# Altium schematic sheet DC_DC_Converter.SchDoc
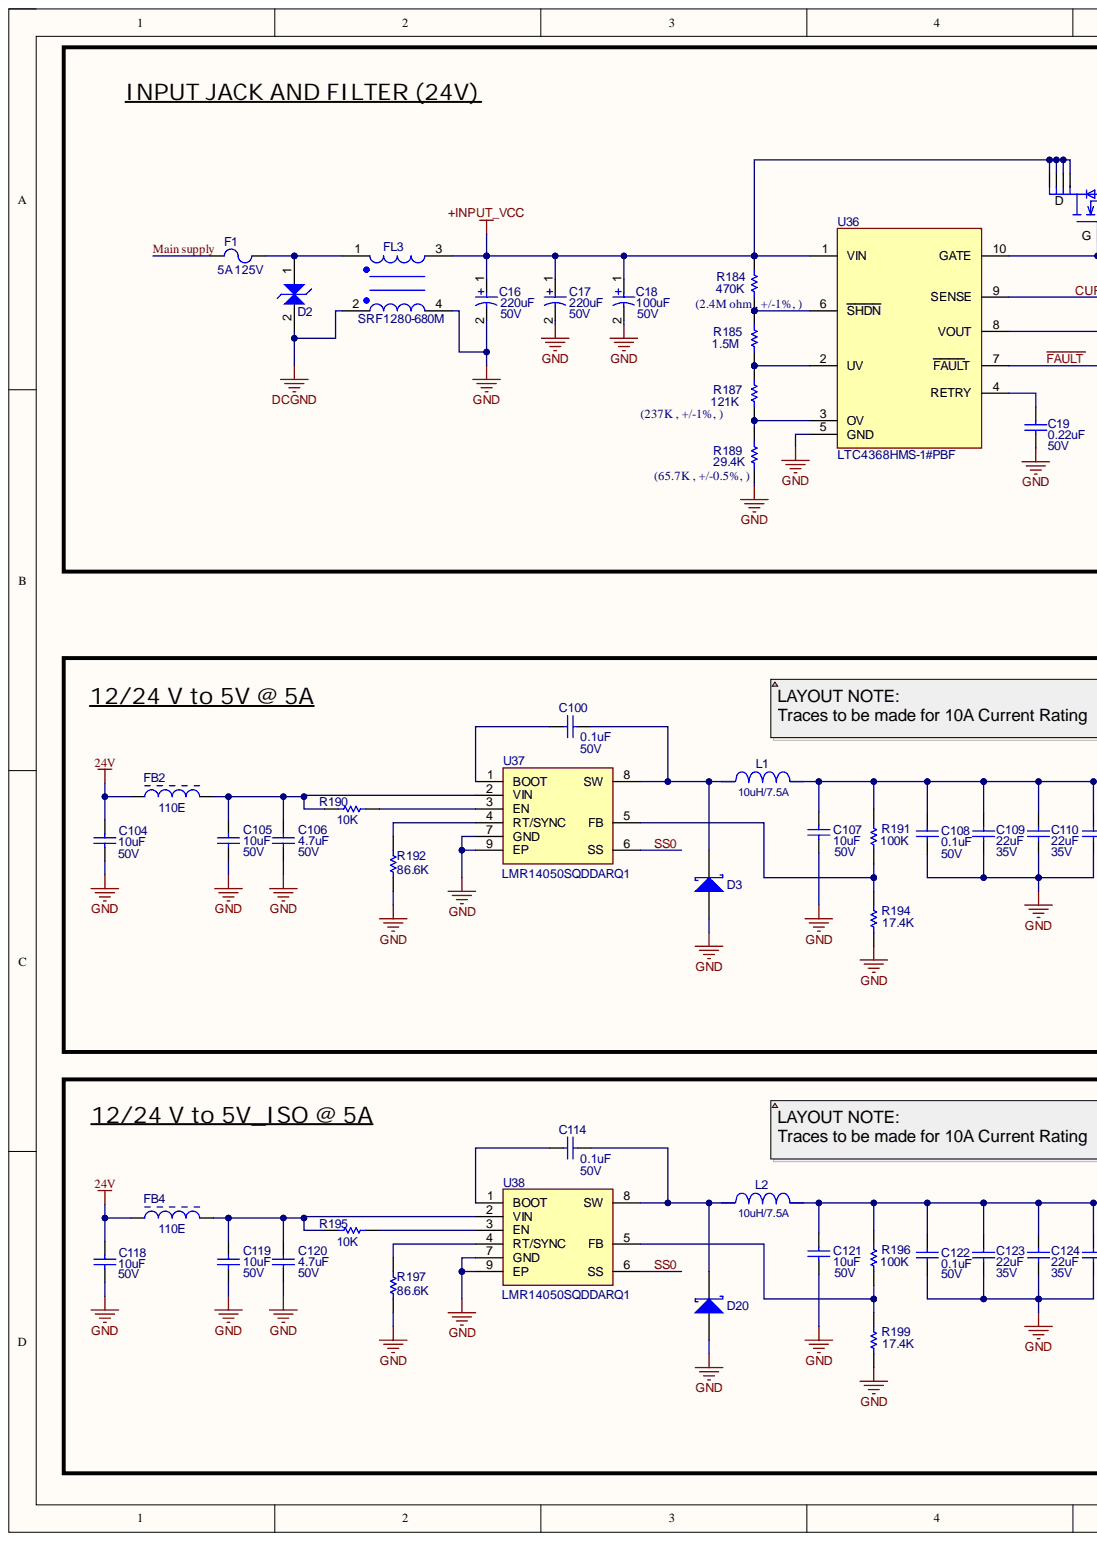
[diagram p0: DC_DC_Converter.SchDoc, left]
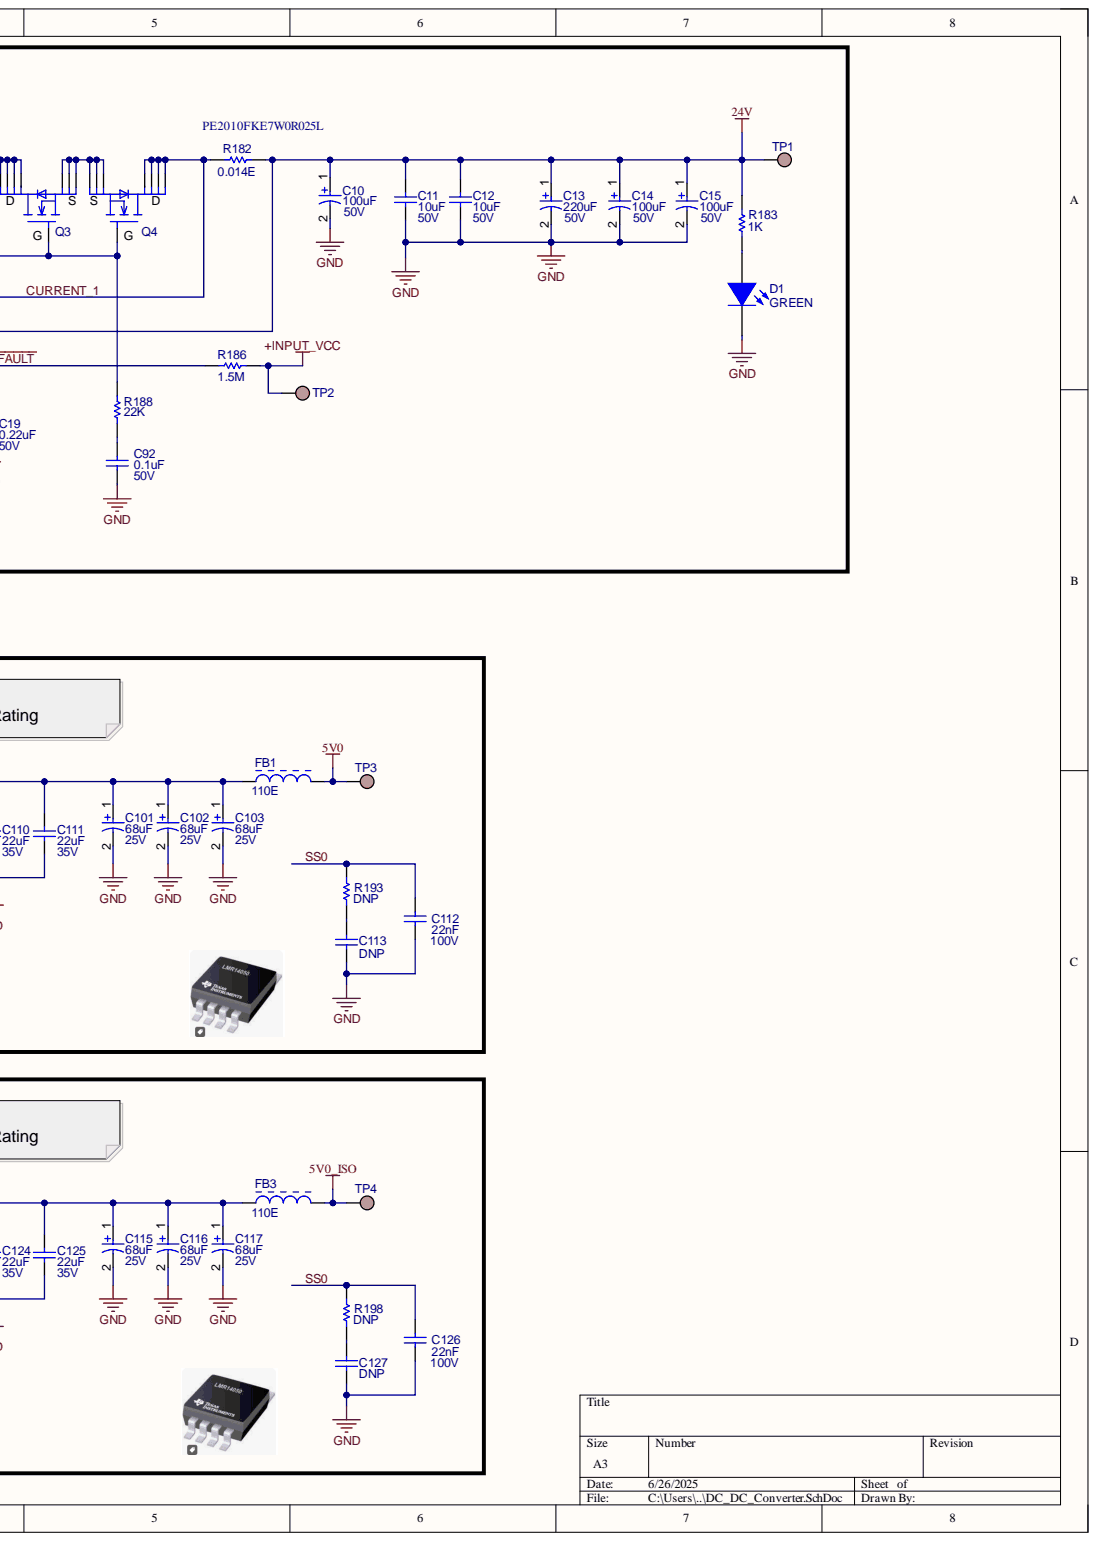
[diagram p1: DC_DC_Converter.SchDoc, right]

## Parts
C10  100uF/50V  value 100uF  Voltage Rating: 50V  [CAP_CAN_8MM]
C11  10uF  Voltage Rating: 50V  [CAP-0805]
C12  10uF  Voltage Rating: 50V  [CAP-0805]
C13  220uF/50V  value 220uF  Voltage Rating: 50V  [CAP_CAN_8MM]
C14  100uF/50V  value 100uF  Voltage Rating: 50V  [CAP_CAN_8MM]
C15  100uF/50V  value 100uF  Voltage Rating: 50V  [CAP_CAN_8MM]
C16  220uF/50V  value 220uF  Voltage Rating: 50V  [CAP_CAN_8MM]
C17  220uF/50V  value 220uF  Voltage Rating: 50V  [CAP_CAN_8MM]
C18  100uF/50V  value 100uF  Voltage Rating: 50V  [CAP_CAN_8MM]
C19  0.22uF  Voltage Rating: 50V  [CAP-0805]
C92  0.1uF  Voltage: 50V  [CAP-0805]
C100  0.1uF  Voltage: 50V  [CAP-0805]
C101  68uF  Voltage Rating: 25V  [CAP_EEHZA1H220P]
C102  68uF  Voltage Rating: 25V  [CAP_EEHZA1H220P]
C103  68uF  Voltage Rating: 25V  [CAP_EEHZA1H220P]
C104  10uF  Voltage: 50V  [CAP-0805]
C105  10uF  Voltage: 50V  [CAP-0805]
C106  4.7uF  Voltage: 50V  [CAP-0805]
C107  10uF  Voltage: 50V  [CAP-0805]
C108  0.1uF  Voltage: 50V  [CAP-0805]
C109  22uF  Voltage Rating: 35V  [CAP-0805]
C110  22uF  Voltage Rating: 35V  [CAP-0805]
C111  22uF  Voltage Rating: 35V  [CAP-0805]
C112  22nF  Voltage: 100V  [CAP-0603]
C113  DNP  [CAP-0603]
C114  0.1uF  Voltage: 50V  [CAP-0805]
C115  68uF  Voltage Rating: 25V  [CAP_EEHZA1H220P]
C116  68uF  Voltage Rating: 25V  [CAP_EEHZA1H220P]
C117  68uF  Voltage Rating: 25V  [CAP_EEHZA1H220P]
C118  10uF  Voltage: 50V  [CAP-0805]
C119  10uF  Voltage: 50V  [CAP-0805]
C120  4.7uF  Voltage: 50V  [CAP-0805]
C121  10uF  Voltage: 50V  [CAP-0805]
C122  0.1uF  Voltage: 50V  [CAP-0805]
C123  22uF  Voltage Rating: 35V  [CAP-0805]
C124  22uF  Voltage Rating: 35V  [CAP-0805]
C125  22uF  Voltage Rating: 35V  [CAP-0805]
C126  22nF  Voltage: 100V  [CAP-0603]
C127  DNP  [CAP-0603]
D1  value GREEN  [LED_0805]  (hidden comment: LTST-C170GKT)
D2  SMAJ40CA  [DO-214AC]  (value not drawn)
D3  SK810L-TP  [DO-214AB (SMC)]  (value not drawn)
D20  SK810L-TP  [DO-214AB (SMC)]  (value not drawn)
F1  5A 125V  Current - Hold: 5A  [FUSE_0157005.DR]
FB1  110E  [FB-0805]
FB2  110E  [FB-0805]
FB3  110E  [FB-0805]
FB4  110E  [FB-0805]
FL3  SRF1280-680M  [SRF1280-680M]
L1  10uH/7.5A  [APCI00121210100T80]
L2  10uH/7.5A  [APCI00121210100T80]
Q3  100V 18.8A  [DFN-8 (5x6)]  (value not drawn)
Q4  100V 18.8A  [DFN-8 (5x6)]  (value not drawn)
R182  0.014E  [RES_2725]
R183  1K  [RES_0805]
R184  470K  [RES_0805]
R185  1.5M  [RES_0805]
R186  1.5M  [RES_0805]
R187  121K  [RES_0805]
R188  22K  [RES-0603]
R189  29.4K  [RES_0805]
R190  10K  [RES-0603]
R191  100K  [RES_0805]
R192  86.6K  [RES_0805]
R193  DNP  [RES-0603]
R194  17.4K  [RES_0805]
R195  10K  [RES-0603]
R196  100K  [RES_0805]
R197  86.6K  [RES_0805]
R198  DNP  [RES-0603]
R199  17.4K  [RES_0805]
TP1  TEST POINT  [TEST_POINT]  (value not drawn)
TP2  TEST POINT  [TEST_POINT]  (value not drawn)
TP3  TEST POINT  [TEST_POINT]  (value not drawn)
TP4  TEST POINT  [TEST_POINT]  (value not drawn)
U36  LTC4368HMS-1#PBF  [10-MSOP]
U37  LMR14050SQDDARQ1  [IC-8-PowerSOIC-9N]
U38  LMR14050SQDDARQ1  [IC-8-PowerSOIC-9N]

## Nets
5V0: FB1.2 TP3.1
5V0_ISO: FB3.2 TP4.1
24V: C10.1 C11.2 C12.2 C13.1 C14.1 C15.1 C104.2 C118.2 FB2.1 FB4.1 R182.1 R183.2 TP1.1 U36.8(VOUT)
+INPUT_VCC: C16.1 C17.1 C18.1 FL3.3 Q3.5 Q3.6 Q3.7(D) Q3.8 R184.1 R186.2 TP2.1 U36.1(VIN)
DCGND: D2.2 FL3.2
GND: C10.2 C11.1 C12.1 C13.2 C14.2 C15.2 C16.2 C17.2 C18.2 C19.1 C92.1 C101.2 C102.2 C103.2 C104.1 C105.1 C106.1 C107.1 C108.1 C109.1 C110.1 C111.1 C112.1 C113.1 C115.2 C116.2 C117.2 C118.1 C119.1 C120.1 C121.1 C122.1 C123.1 C124.1 C125.1 C126.1 C127.1 D1.C D3.1 D20.1 FL3.4 R189.2 R192.1 R194.1 R197.1 R199.1 U36.5(GND) U37.7(GND) U37.9(EP) U38.7(GND) U38.9(EP)
CURRENT_1: Q4.5 Q4.6 Q4.7(D) Q4.8 R182.2 U36.9(SENSE)
~{FAULT}: R186.1 U36.7(FAULT)
Main supply: F1.1
SS0: C112.2 C126.2 R193.2 R198.2 U37.6(SS) U38.6(SS)
NetC19_2: C19.2 U36.4(RETRY)
NetC92_2: C92.2 R188.2
NetC100_1: C100.1 D3.2 L1.2 U37.8(SW)
NetC100_2: C100.2 U37.1(BOOT)
NetC101_1: C101.1 C102.1 C103.1 C107.2 C108.2 C109.2 C110.2 C111.2 FB1.1 L1.1 R191.2
NetC105_2: C105.2 C106.2 FB2.2 R190.1 U37.2(VIN)
NetC113_2: C113.2 R193.1
NetC114_1: C114.1 D20.2 L2.2 U38.8(SW)
NetC114_2: C114.2 U38.1(BOOT)
NetC115_1: C115.1 C116.1 C117.1 C121.2 C122.2 C123.2 C124.2 C125.2 FB3.1 L2.1 R196.2
NetC119_2: C119.2 C120.2 FB4.2 R195.1 U38.2(VIN)
NetC127_2: C127.2 R198.1
NetD1_A: D1.A R183.1
NetD2_1: D2.1 F1.2 FL3.1
NetQ3_1: Q3.1(S) Q3.2 Q3.3 Q4.1 Q4.2(S) Q4.3
NetQ3_4: Q3.4 Q4.4 R188.1 U36.10(GATE)
NetR184_2: R184.2 R185.1 U36.6(SHDN)
NetR185_2: R185.2 R187.1 U36.2(UV)
NetR187_2: R187.2 R189.1 U36.3(OV)
NetR190_2: R190.2 U37.3(EN)
NetR191_1: R191.1 R194.2 U37.5(FB)
NetR192_2: R192.2 U37.4(RT/SYNC)
NetR195_2: R195.2 U38.3(EN)
NetR196_1: R196.1 R199.2 U38.5(FB)
NetR197_2: R197.2 U38.4(RT/SYNC)

## Library data of the parts
C10 C14 C15 C18 = CAP-P-2: CAP ALUMHYB 100UF 20% 50V SMD; Manufacturer: Nichicon; Part Number: GYF1H101MCQ1GS; ESR: 30 mOhms; Tolerance: ±1%; Component Kind: Standard; Library Name: Daughter_board_SE3_V1.SCHLIB
C11 C12 = CAP_0805_N: CAP CER 10UF 50V X5R 0805; Manufacturer: Murata Electronics; Part Number: GRM21BR61H106KE43K; Tolerance: ±1%; Component Kind: Standard; Library Name: MB_Sch_Lib.SchLib; Operating Temperature: -55C ~ 85C; Package / Case: 0805 (2012 metric); Temperature Coefficient: X5R; Value: 10uF
C13 C16 C17 = CAP-P-2: CAP ALUMHYB 220UF 20% 50V SMD; Manufacturer: Chemi-Con; Part Number: HHXE500GRA221MJH0G; ESR: 16mOhms; Tolerance: ±1%; Component Kind: Standard; Library Name: Daughter_board_SE3_V1.SCHLIB
C19 = CAP_0805_N: CAP CER 0.22UF 50V X7R 0805; Manufacturer: KYOCERA AVX; Part Number: KAM21KR71H224KU; Tolerance: ±1%; Component Kind: Standard; Library Name: MB_Sch_Lib.SchLib; Operating Temperature: -55C ~ 125C; Package / Case: 0805; Temperature Coefficient: X7R; Value: 0.22uF
C92 = GRM155R71C104KA88D: CAP CER 0.1UF 50V X7R 0805; Manufacturer: KYOCERA AVX; Part Number: 08055C104J4Z2A; Component Kind: Standard; Library Name: Capacitor_Ceramic.IntLib; Signal Integrity: Cap; Simulation: Cap; Value: 0.1uF
C100 C114 = CAP: CAP CER 0.1UF 50V X7R 0805; Manufacturer: KYOCERA AVX; Part Number: 08055C104J4Z2A; Tolerance: ±1%; Component Kind: Standard; Library Name: Daughter_board_SE3_V1.SCHLIB; Package: 0805; Technology: SMT; Value: 0.1uF
C101-C103 C115-C117 = CAP-P-2: CAP ALUM HYBRID 68UF 20% 25V SMD; Manufacturer: KEMET; Part Number: EEH-ZA1E680XP; ESR: 30mOhms; Component Kind: Standard; Library Name: Daughter_board_SE3_V1.SCHLIB; Package / Case: Radial; Tolerance: ±20%; Value: 68uF
C104 C105 C107 C118 C119 C121 = CAP: CAP CER 10UF 50V X5R 0805; Manufacturer: Murata Electronics; Part Number: GRM21BR61H106ME43L; Tolerance: ±1%; Component Kind: Standard; Library Name: Daughter_board_SE3_V1.SCHLIB; Package: 0805; Value: 10uF
C106 C120 = CAP: CAP CER 4.7UF 50V X5R 0805; Manufacturer: Murata Electronics; Part Number: GRM21BR61H475KE51L; Tolerance: ±1%; Component Kind: Standard; Library Name: Daughter_board_SE3_V1.SCHLIB; Package: 0805; Value: 4.7uF
C108 C122 = CAP: CAP CER 0.1UF 50V X7R 0805; Manufacturer: KYOCERA AVX; Part Number: 08055C104J4Z2A; Component Kind: Standard; Library Name: CAN_20-06-2023.SCHLIB; Package: 0603; Value: 0.1uF
C109-C111 C123-C125 = CAP_0805_N: CAP CER 22UF 35V X5R 0805; Manufacturer: TDK Corporation; Part Number: C2012X5R1V226M125AC; Tolerance: ±1%; Component Kind: Standard; Library Name: MB_Sch_Lib.SchLib; Operating Temperature: -55C ~ 85C; Package / Case: 0805 (2012 metric); Temperature Coefficient: X5R; Value: 22uF
C112 C126 = CAP: CAP CER 0.022UF 100V X7R 0603; Manufacturer: Murata Electronics; Part Number: GCM188R72A223KA37D; Case-Metric: 1608; Tolerance: ±10%; Component Kind: Standard; Library Name: Daughter_board_SE3_V1.SCHLIB; Package: 0603; Technology: SMT; Value: 22nF
C113 C127 = CAP: NA; Case-Metric: 1608; Tolerance: ±5%; Component Kind: Standard; Library Name: Daughter_board_SE3_V1.SCHLIB; Manufacturer: NA; Package: 0603; Part Number: NA; Technology: SMT; Value: DNP; Voltage: NA
D1 = LED_A2_K1: LED GREEN CLEAR SMD; Manufacturer: Lite-On Inc.; Component Kind: Standard; ComponentLink1Description: Datasheet link; ComponentLink1URL: http://katalog.we-online.de/led/datasheet/150080SS75000.pdf; Library Name: Miscellaneous Devices.IntLib; Package: 0805; Part Number: LTST-C170GKT
D2 = SMCJ12CA: TVS DIODE 36VWM 58.1VC DO214AC; Manufacturer: STMicroelectronics; Part Number: SMAJ36CA; Component Kind: Standard; Library Name: SamacSys.SchLib; Package: SOD-323; Value: 36VWM
D3 D20 = DSKY-AK-2: DIODE SCHOTTKY 100V 8A DO214AB; Manufacturer: MCC (Micro Commercial Components); Average Rectified Current-Max: 8A; Component Kind: Standard; Library Name: Daughter_board_SE3_V1.SCHLIB; Mounting Technology: SurfaceMount; Part Number: SK810L-TP; Reverse Voltage-Max [Vrrm]: 100V
F1 = FUSE-2: FUSE BRD MNT 5A 125VAC/VDC 2SMD; Manufacturer: Littelfuse Inc.; Part Number: 0157005.DR; Current - Maximum: 50A; Current - Trip: 14A; Voltage - Maximum: 24V; Component Kind: Standard; Library Name: Daughter_board_SE3_V1.SCHLIB; Operating Temperature Range: -40Cto+85C; Package / Case: 2920 (7351 Metric); Termination Style: SMD/SMT
FB1 FB3 = IND-FB-2: FERRITE BEAD 110 OHM 0805 1LN; Manufacturer: Murata Electronics; Part Number: BLM21SP111SZ1D; Current: 5A; Value: 110 Ohm; Component Kind: Standard; Library Name: Daughter_board_SE3_V1.SCHLIB; Package Reference: 0805
FB2 FB4 = IND-FB-2: FERRITE BEAD 110 OHM 0805 1LN; Manufacturer: Murata Electronics; Part Number: BLM21SP111SZ1D; Test Frequency: 100MHz; Component Kind: Standard; Library Name: Daughter_board_SE3_V1.SCHLIB; Package: 0805(2012metric); Termination Style: SMD/SMT; Value: 110E
FL3 = SRF1280-680M: INDUCT ARRAY 2 COIL 68UH SMD; Manufacturer: Bourns Inc.; Value: 68µH; Component Kind: Standard; Package: None; Part Number: SRF1280-680M
L1 L2 = XAL6060-472MEB: FIXED IND 10UH 7.5A 18.3MOHM; Manufacturer: Pulse Electronics; Component Kind: Standard; Library Name: TI WEBENCH; Package: SMD/SMT; Part Number: APCI00121210100T80; Value: 10uH/7.5A
Q3 Q4 = AONR21357: MOSFET N-CH 100V 18.8A/81A PPAK; Manufacturer: Vishay Siliconix; Part Number: SIR870BDP-T1-RE3; Component Kind: Standard; Library Name: MB_Sch_Lib.SchLib; Package / Case: DFN-8(5x6)
R182 = RES-2: 2T WPC2512 3W 50PPM 14 MOHM 1.0%; Manufacturer: Littelfuse Inc.; Part Number: WPC2512QLR014FYR; Component Kind: Standard; Library Name: Daughter_board_SE3_V1.SCHLIB; Package: 2725; Value: 0.014E
R183 = RES-2: RES SMD 1K OHM 1% 1/8W 0805; Manufacturer: YAGEO; Part Number: AC0805FR-071KL; Power Rating: 100mW; Component Kind: Standard; Library Name: Daughter_board_SE3_V1.SCHLIB; Package: 0805; Tolerance: ±1%; Value: 1K
R184 = RES-2: RES SMD 470K OHM 1% 1/8W 0805; Manufacturer: YAGEO; Part Number: AC0805FR-07470KL; Component Kind: Standard; Library Name: Daughter_board_SE3_V1.SCHLIB; Package: 0805; Value: 470K
R185 R186 = RES-2: RES SMD 1.5M OHM 1% 1/8W 0805; Manufacturer: YAGEO; Part Number: AC0805FR-071M5L; Component Kind: Standard; Library Name: Daughter_board_SE3_V1.SCHLIB; Package: 0805; Value: 1.5M
R187 = RES-2: RES 121K OHM 1% 1/8W 0805; Manufacturer: YAGEO; Part Number: RC0805FR-07121KL; Component Kind: Standard; Library Name: Daughter_board_SE3_V1.SCHLIB; Package: 0805; Value: 121K
R188 = RES-2: RES 22K OHM 1% 1/10W 0603; Manufacturer: YAGEO; Part Number: AC0603FR-1322KL; Power Rating: 100mW; Case/Package: 0603; Component Kind: Standard; Library Name: Daughter_board_SE3_V1.SCHLIB; Tolerance: ±1%; Value: 22K
R189 = RES-2: RES SMD 29.4K OHM 1% 1/8W 0805; Manufacturer: YAGEO; Part Number: AC0805FR-0729K4L; Component Kind: Standard; Library Name: Daughter_board_SE3_V1.SCHLIB; Package: 0805; Value: 29.4K
R190 R195 = RES-2: RES SMD 10K OHM 1% 1/10W 0603; Manufacturer: YAGEO; Part Number: AC0603FR-0710KL; Power Rating: 100mW; Component Kind: Standard; Library Name: Daughter_board_SE3_V1.SCHLIB; Package: 0603; Tolerance: ±1%; Value: 10K
R191 R196 = RES-2: RES SMD 100K OHM 1% 1/8W 0805; Manufacturer: YAGEO; Part Number: AC0805FR-07100KL; Case/Package: 0805; Component Kind: Standard; Library Name: Daughter_board_SE3_V1.SCHLIB; Tolerance: ±1%; Value: 100K
R192 R197 = RES-2: RES 86.6K OHM 1% 1/8W 0805; Manufacturer: YAGEO; Part Number: RC0805FR-0786K6L; Power Rating: 100mW; Case/Package: 0805; Component Kind: Standard; Library Name: Daughter_board_SE3_V1.SCHLIB; Tolerance: ±1%; Value: 86.6K
R193 R198 = RES-2: NA; Tolerance: ±1%; Case/Package: 0603; Component Kind: Standard; Library Name: Daughter_board_SE3_V1.SCHLIB; Manufacturer: NA; Part Number: NA; Value: DNP
R194 R199 = RES-2: RES 17.4K OHM 1% 1/8W 0805; Manufacturer: YAGEO; Part Number: RC0805FR-0717K4L; Case/Package: 0805; Component Kind: Standard; Library Name: Daughter_board_SE3_V1.SCHLIB; Tolerance: ±1%; Value: 17.4K
TP1-TP4 = TP: Test Point 0.66mm DIA; Component Kind: Standard; Part Number: Test Point; Library Name: GENSET CONTROLLER BETA V1.SCHLIB
U36 = LTC4368CMS-1#PBF: IC SURGE SUPPRESSION ADJ 10MSOP; Manufacturer: Analog Devices Inc.; Component Kind: Standard; Package: 10-MSOP; Part Number: LTC4368HMS-1#PBF; Library Name: GENSET CONTROLLER BETA V1.SCHLIB
U37 U38 = TPS54531DDAR: IC REG BUCK ADJ 5A 8SOPWR; Manufacturer: Diodes Incorporated; Value: 4-40V; Component Kind: Standard; Package: SO-PowerPad-8; Part Number: LMR14050SQDDARQ1; Library Name: MOTHERBOARD_PI_COMPUTE_MODULE_4_1.SCHLIB
